# Altium schematic sheet Z18B_STMF_SL_PP0_180928.SchDoc
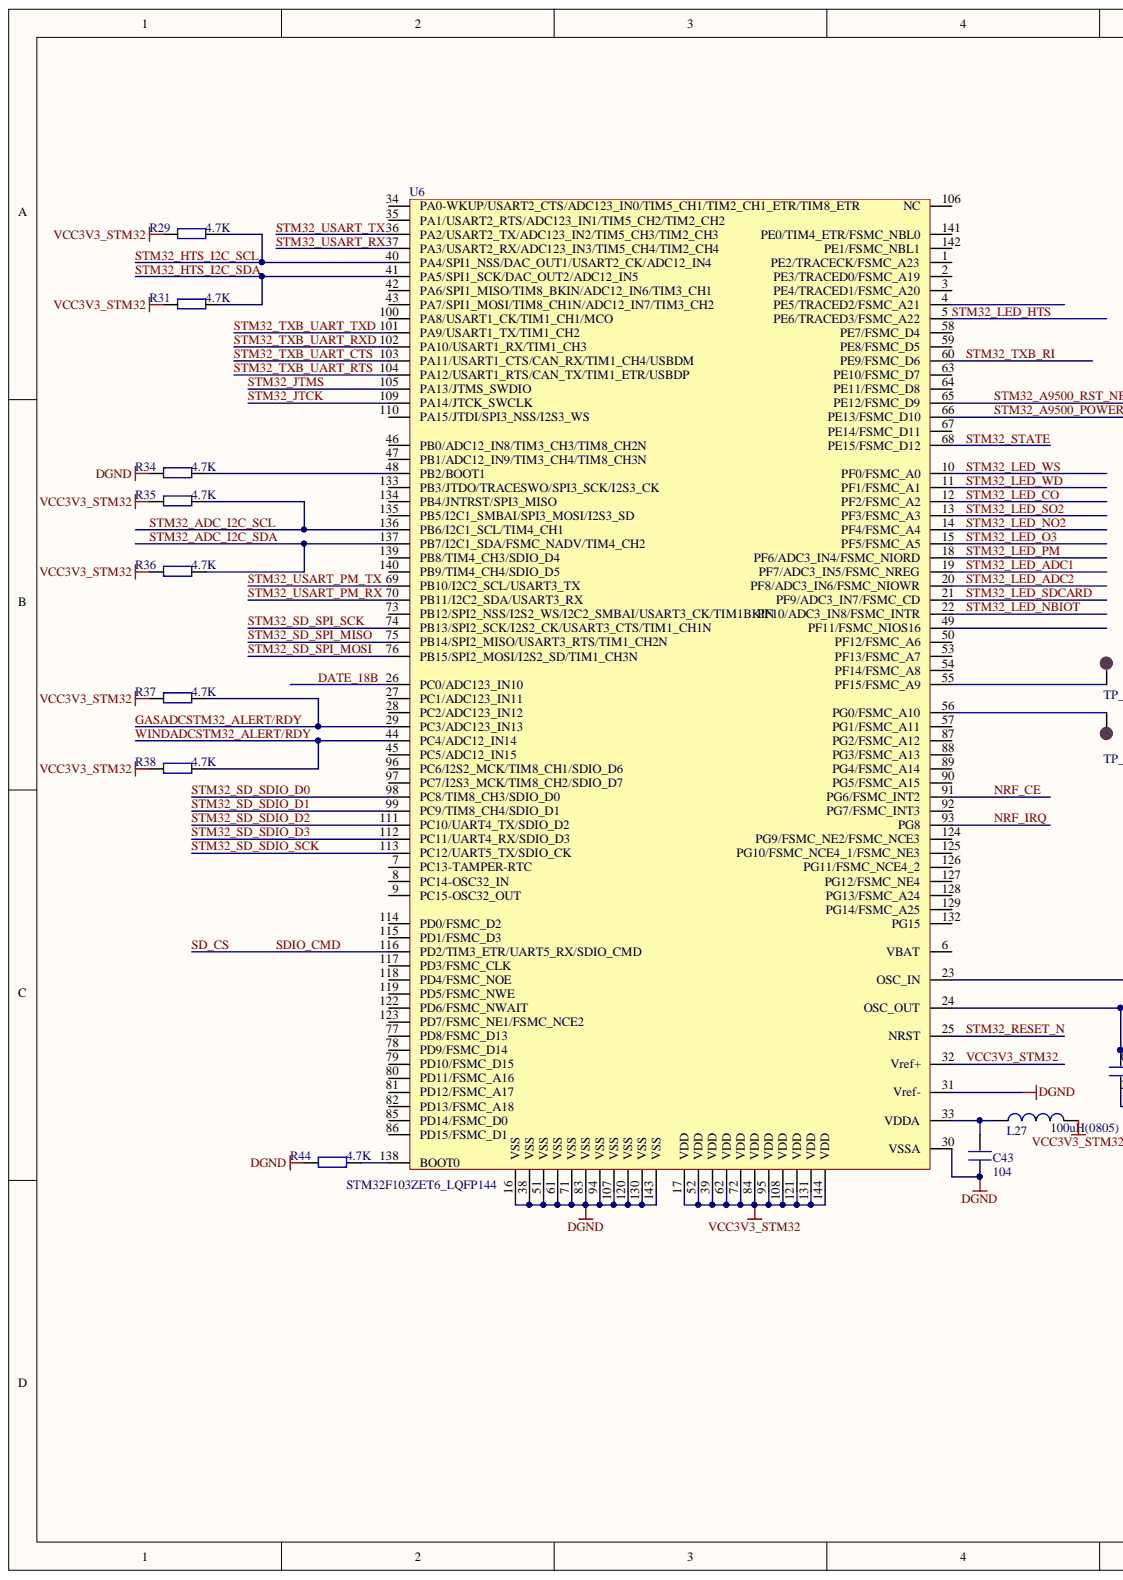
[diagram p0: Z18B_STMF_SL_PP0_180928.SchDoc, left]
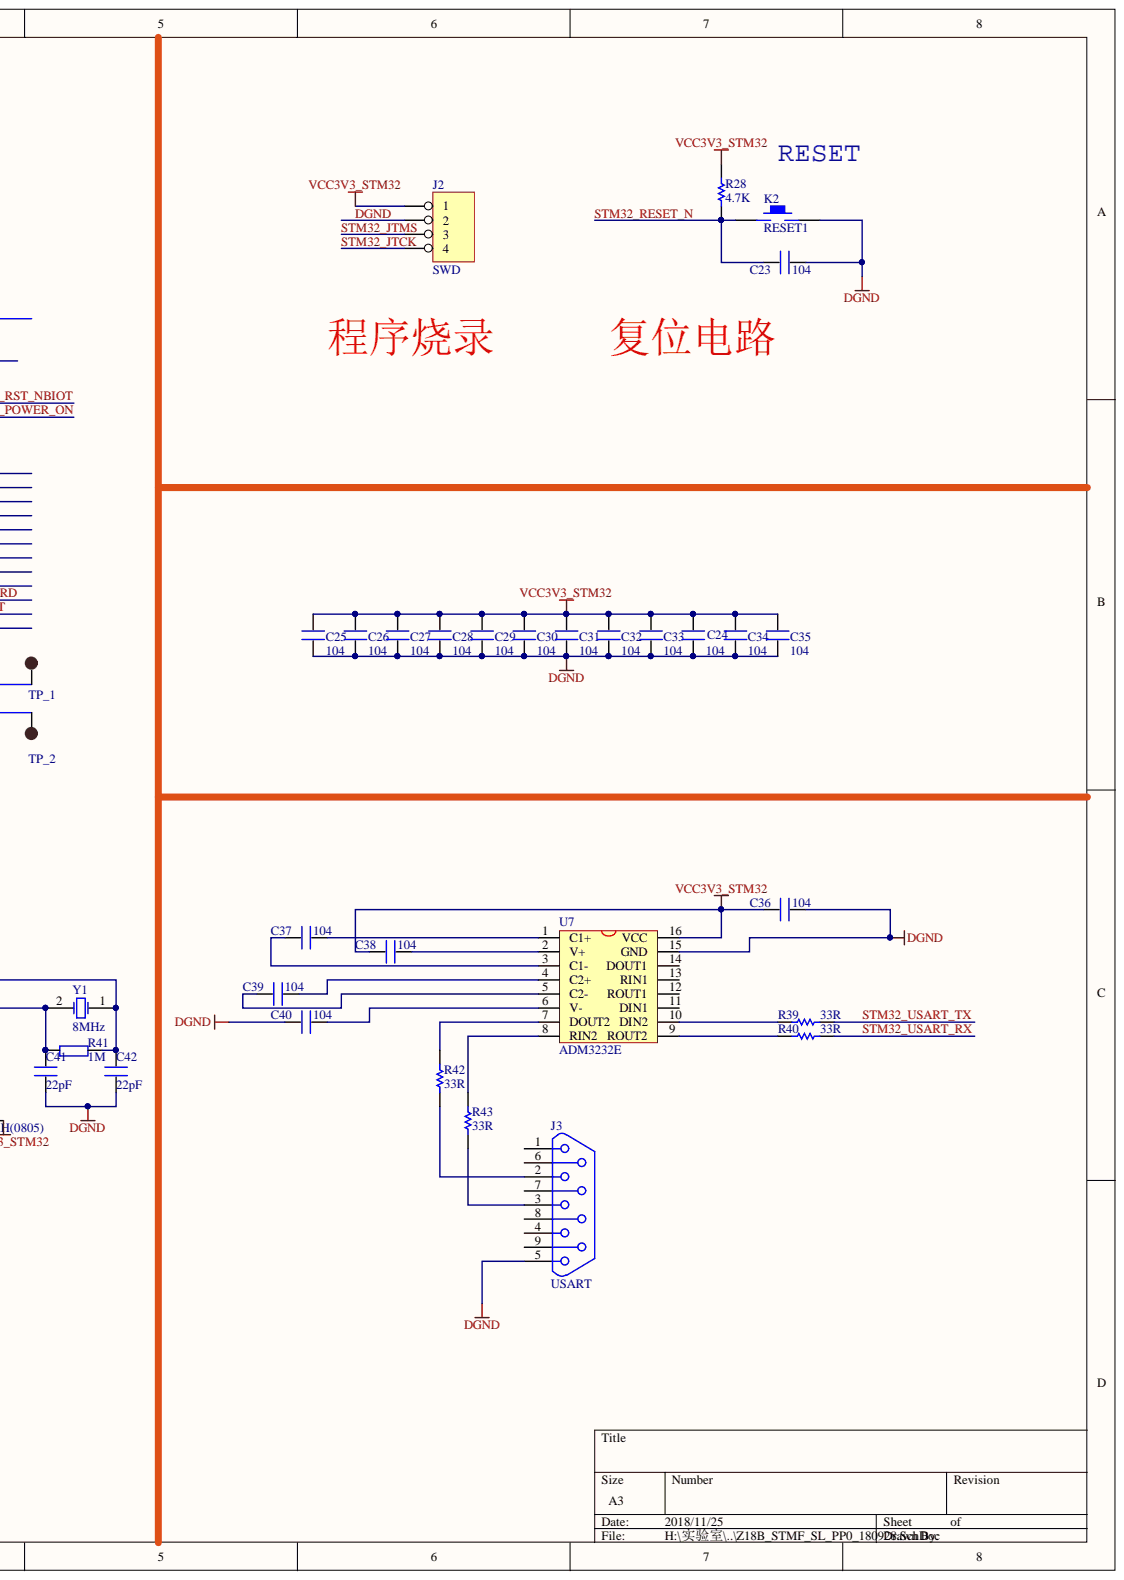
[diagram p1: Z18B_STMF_SL_PP0_180928.SchDoc, right]

## Parts
C23  104  [C0603]
C24  104  [C0603]
C25  104  [C0603]
C26  104  [C0603]
C27  104  [C0603]
C28  104  [C0603]
C29  104  [C0603]
C30  104  [C0603]
C31  104  [C0603]
C32  104  [C0603]
C33  104  [C0603]
C34  104  [C0603]
C35  104  [C0603]
C36  104  [C0603]
C37  104  [C0603]
C38  104  [C0603]
C39  104  [C0603]
C40  104  [C0603]
C41  22pF  [C0603]
C42  22pF  [C0603]
C43  104  [C0603]
J2  SWD  [SIP-2.0-4]
J3  USART  [DB9]
L27  100uH(0805)  [0805]
R28  4.7K  [R0603]
R29  4.7K  [R0603]
R31  4.7K  [R0603]
R34  4.7K  [R0603]
R35  4.7K  [R0603]
R36  4.7K  [R0603]
R37  4.7K  [R0603]
R38  4.7K  [R0603]
R39  value 33R  [R0603]  (hidden comment: Res1)
R40  value 33R  [R0603]  (hidden comment: Res1)
R41  1M  [R0603]
R42  value 33R  [R0603]  (hidden comment: Res1)
R43  value 33R  [R0603]  (hidden comment: Res1)
R44  4.7K  [R0603]
RESET1  K2  [KEY_M]
TP_1  TEST POINT  [TP_2mm]  (value not drawn)
TP_2  TEST POINT  [TP_2mm]  (value not drawn)
U6  STM32F103ZET6_LQFP144  [LQFP144]
U7  ADM3232E  [SOP16M]
Y1  8MHz  [3225晶振 - pin2]

## Nets
DATE_18B: U6.26(PC0/ADC123_IN10)
DGND: C23.2 C24.2 C25.2 C26.1 C27.1 C28.1 C29.1 C30.1 C31.2 C32.2 C33.2 C34.2 C35.2 C36.2 C40.1 C41.1 C42.1 C43.1 J2.2 J3.5 R34.2 R44.2 RESET1.2 U6.16(VSS) U6.30(VSSA) U6.31(Vref-) U6.38(VSS) U6.51(VSS) U6.61(VSS) U6.71(VSS) U6.83(VSS) U6.94(VSS) U6.107(VSS) U6.120(VSS) U6.130(VSS) U6.143(VSS) U7.15(GND)
GASADCSTM32_ALERT/RDY: R37.1 U6.29(PC3/ADC123_IN13)
NRF_CE: U6.91(PG6/FSMC_INT2)
NRF_IRQ: U6.93(PG8)
SD_CS: U6.116(PD2/TIM3_ETR/UART5_RX/SDIO_CMD)
STM32_A9500_POWER_ON: U6.66(PE13/FSMC_D10)
STM32_A9500_RST_NBIOT: U6.65(PE12/FSMC_D9)
STM32_ADC_I2C_SCL: R35.1 U6.136(PB6/I2C1_SCL/TIM4_CH1)
STM32_ADC_I2C_SDA: R36.1 U6.137(PB7/I2C1_SDA/FSMC_NADV/TIM4_CH2)
STM32_HTS_I2C_SCL: R29.1 U6.40(PA4/SPI1_NSS/DAC_OUT1/USART2_CK/ADC12_IN4)
STM32_HTS_I2C_SDA: R31.1 U6.41(PA5/SPI1_SCK/DAC_OUT2/ADC12_IN5)
STM32_JTCK: J2.4 U6.109(PA14/JTCK_SWCLK)
STM32_JTMS: J2.3 U6.105(PA13/JTMS_SWDIO)
STM32_LED_ADC1: U6.19(PF7/ADC3_IN5/FSMC_NREG)
STM32_LED_ADC2: U6.20(PF8/ADC3_IN6/FSMC_NIOWR)
STM32_LED_CO: U6.12(PF2/FSMC_A2)
STM32_LED_HTS: U6.5(PE6/TRACED3/FSMC_A22)
STM32_LED_NBIOT: U6.22(PF10/ADC3_IN8/FSMC_INTR)
STM32_LED_NO2: U6.14(PF4/FSMC_A4)
STM32_LED_O3: U6.15(PF5/FSMC_A5)
STM32_LED_PM: U6.18(PF6/ADC3_IN4/FSMC_NIORD)
STM32_LED_SDCARD: U6.21(PF9/ADC3_IN7/FSMC_CD)
STM32_LED_SO2: U6.13(PF3/FSMC_A3)
STM32_LED_WD: U6.11(PF1/FSMC_A1)
STM32_LED_WS: U6.10(PF0/FSMC_A0)
STM32_RESET_N: C23.1 R28.1 RESET1.1 U6.25(NRST)
STM32_SD_SDIO_D0: U6.98(PC8/TIM8_CH3/SDIO_D0)
STM32_SD_SDIO_D1: U6.99(PC9/TIM8_CH4/SDIO_D1)
STM32_SD_SDIO_D2: U6.111(PC10/UART4_TX/SDIO_D2)
STM32_SD_SDIO_D3: U6.112(PC11/UART4_RX/SDIO_D3)
STM32_SD_SDIO_SCK: U6.113(PC12/UART5_TX/SDIO_CK)
STM32_SD_SPI_MISO: U6.75(PB14/SPI2_MISO/USART3_RTS/TIM1_CH2N)
STM32_SD_SPI_MOSI: U6.76(PB15/SPI2_MOSI/I2S2_SD/TIM1_CH3N)
STM32_SD_SPI_SCK: U6.74(PB13/SPI2_SCK/I2S2_CK/USART3_CTS/TIM1_CH1N)
STM32_STATE: U6.68(PE15/FSMC_D12)
STM32_TXB_RI: U6.60(PE9/FSMC_D6)
STM32_TXB_UART_CTS: U6.103(PA11/USART1_CTS/CAN_RX/TIM1_CH4/USBDM)
STM32_TXB_UART_RTS: U6.104(PA12/USART1_RTS/CAN_TX/TIM1_ETR/USBDP)
STM32_TXB_UART_RXD: U6.102(PA10/USART1_RX/TIM1_CH3)
STM32_TXB_UART_TXD: U6.101(PA9/USART1_TX/TIM1_CH2)
STM32_USART_PM_RX: U6.70(PB11/I2C2_SDA/USART3_RX)
STM32_USART_PM_TX: U6.69(PB10/I2C2_SCL/USART3_TX)
STM32_USART_RX: R40.2 U6.37(PA3/USART2_RX/ADC123_IN3/TIM5_CH4/TIM2_CH4)
STM32_USART_TX: R39.2 U6.36(PA2/USART2_TX/ADC123_IN2/TIM5_CH3/TIM2_CH3)
VCC3V3_STM32: C24.1 C25.1 C26.2 C27.2 C28.2 C29.2 C30.2 C31.1 C32.1 C33.1 C34.1 C35.1 C36.1 C38.1 J2.1 L27.2 R28.2 R29.2 R31.2 R35.2 R36.2 R37.2 R38.2 U6.17(VDD) U6.32(Vref+) U6.39(VDD) U6.52(VDD) U6.62(VDD) U6.72(VDD) U6.84(VDD) U6.95(VDD) U6.108(VDD) U6.121(VDD) U6.131(VDD) U6.144(VDD) U7.16(VCC)
WINDADCSTM32_ALERT/RDY: R38.1 U6.44(PC4/ADC12_IN14)
NetC37_1: C37.1 U7.3(C1-)
NetC37_2: C37.2 U7.1(C1+)
NetC38_2: C38.2 U7.2(V+)
NetC39_1: C39.1 U7.5(C2-)
NetC39_2: C39.2 U7.4(C2+)
NetC40_2: C40.2 U7.6(V-)
NetC41_2: C41.2 R41.1 U6.24(OSC_OUT) Y1.2
NetC42_2: C42.2 R41.2 U6.23(OSC_IN) Y1.1
NetC43_2: C43.2 L27.1 U6.33(VDDA)
NetJ3_2: J3.2 R42.1
NetJ3_3: J3.3 R43.2
NetR34_1: R34.1 U6.48(PB2/BOOT1)
NetR39_1: R39.1 U7.10(DIN2)
NetR40_1: R40.1 U7.9(ROUT2)
NetR42_2: R42.2 U7.7(DOUT2)
NetR43_1: R43.1 U7.8(RIN2)
NetR44_1: R44.1 U6.138(BOOT0)
NetTP_1_1: TP_1.1 U6.55(PF15/FSMC_A9)
NetTP_2_1: TP_2.1 U6.56(PG0/FSMC_A10)

Not connected: J3 1 4 6 7 8 9; U6 1(PE2/TRACECK/FSMC_A23) 2(PE3/TRACED0/FSMC_A19) 3(PE4/TRACED1/FSMC_A20) 4(PE5/TRACED2/FSMC_A21) 6(VBAT) 7(PC13-TAMPER-RTC) 8(PC14-OSC32_IN) 9(PC15-OSC32_OUT) 27(PC1/ADC123_IN11) 28(PC2/ADC123_IN12) 34(PA0-WKUP/USART2_CTS/ADC123_IN0/TIM5_CH1/TIM2_CH1_ETR/TIM8_ETR) 35(PA1/USART2_RTS/ADC123_IN1/TIM5_CH2/TIM2_CH2) 42(PA6/SPI1_MISO/TIM8_BKIN/ADC12_IN6/TIM3_CH1) 43(PA7/SPI1_MOSI/TIM8_CH1N/ADC12_IN7/TIM3_CH2) 45(PC5/ADC12_IN15) 46(PB0/ADC12_IN8/TIM3_CH3/TIM8_CH2N) 47(PB1/ADC12_IN9/TIM3_CH4/TIM8_CH3N) 49(PF11/FSMC_NIOS16) 50(PF12/FSMC_A6) 53(PF13/FSMC_A7) 54(PF14/FSMC_A8) 57(PG1/FSMC_A11) 58(PE7/FSMC_D4) 59(PE8/FSMC_D5) 63(PE10/FSMC_D7) 64(PE11/FSMC_D8) 67(PE14/FSMC_D11) 73(PB12/SPI2_NSS/I2S2_WS/I2C2_SMBAI/USART3_CK/TIM1BKIN) 77(PD8/FSMC_D13) 78(PD9/FSMC_D14) 79(PD10/FSMC_D15) 80(PD11/FSMC_A16) 81(PD12/FSMC_A17) 82(PD13/FSMC_A18) 85(PD14/FSMC_D0) 86(PD15/FSMC_D1) 87(PG2/FSMC_A12) 88(PG3/FSMC_A13) 89(PG4/FSMC_A14) 90(PG5/FSMC_A15) 92(PG7/FSMC_INT3) 96(PC6/I2S2_MCK/TIM8_CH1/SDIO_D6) 97(PC7/I2S3_MCK/TIM8_CH2/SDIO_D7) 100(PA8/USART1_CK/TIM1_CH1/MCO) 106(NC) 110(PA15/JTDI/SPI3_NSS/I2S3_WS) 114(PD0/FSMC_D2) 115(PD1/FSMC_D3) 117(PD3/FSMC_CLK) 118(PD4/FSMC_NOE) 119(PD5/FSMC_NWE) 122(PD6/FSMC_NWAIT) 123(PD7/FSMC_NE1/FSMC_NCE2) 124(PG9/FSMC_NE2/FSMC_NCE3) 125(PG10/FSMC_NCE4_1/FSMC_NE3) 126(PG11/FSMC_NCE4_2) 127(PG12/FSMC_NE4) 128(PG13/FSMC_A24) 129(PG14/FSMC_A25) 132(PG15) 133(PB3/JTDO/TRACESWO/SPI3_SCK/I2S3_CK) 134(PB4/JNTRST/SPI3_MISO) 135(PB5/I2C1_SMBAI/SPI3_MOSI/I2S3_SD) 139(PB8/TIM4_CH3/SDIO_D4) 140(PB9/TIM4_CH4/SDIO_D5) 141(PE0/TIM4_ETR/FSMC_NBL0) 142(PE1/FSMC_NBL1); U7 11(DIN1) 12(ROUT1) 13(RIN1) 14(DOUT1)

## Library data of the parts
C23-C35 C43 = C: Component Kind: Standard; Library Name: 战舰STM32开发板底板封装库.IntLib
C36-C42 = C_1: Component Kind: Standard; Library Name: 战舰STM32开发板底板封装库.IntLib
J2 = 4 HEADER: 4 Pin Header; Component Kind: Standard
J3 = DB9: Component Kind: Standard; Library Name: 战舰STM32开发板底板封装库.IntLib
L27 = Inductor: Code_IEC: 1005; Value: 10mH; Code_JEITA: 0402; Component Kind: Standard; Description: Inductor; LatestRevisionDate: 29-May-2009; LatestRevisionNote: IPC-7351 Footprint Added.; Library Name: Miscellaneous Devices.IntLib; PackageDescription: Chip Inductor; PackageReference: 0402-A; Published: 8-Jun-2000; Signal Integrity: Inductor; Simulation: INDUCTOR; Publisher: Altium Limited
R28 = Res1: Resistor; Value: 1K; Component Kind: Standard; LatestRevisionDate: 17-Jul-2002; LatestRevisionNote: Re-released for DXP Platform.; Library Name: Miscellaneous Devices.IntLib; PackageDescription: Axial Device, Thru-Hole; 2 Leads; 0.3 in Pin Spacing; PackageReference: AXIAL-0.3; Published: 8-Jun-2000; Signal Integrity: Res; Simulation: RESISTOR; Publisher: Altium Limited
R29 R31 R34-R38 R44 = R: Component Kind: Standard; Library Name: 战舰STM32开发板底板封装库.IntLib
R39 R40 R42 R43 = Res1: Resistor; Component Kind: Standard; LatestRevisionDate: 17-Jul-2002; LatestRevisionNote: Re-released for DXP Platform.; Library Name: Miscellaneous Devices.IntLib; PackageDescription: Axial Device, Thru-Hole; 2 Leads; 0.3 in Pin Spacing; PackageReference: AXIAL-0.3; Published: 8-Jun-2000; Signal Integrity: Res; Simulation: RESISTOR; Publisher: Altium Limited
R41 = R_1: Component Kind: Standard; Library Name: 战舰STM32开发板底板封装库.IntLib
RESET1 = KEY_M: Component Kind: Standard; Library Name: 战舰STM32开发板底板封装库.IntLib
TP_1 TP_2 = TEST-POINT: 测试点; Component Kind: Standard; Library Name: 战舰STM32开发板底板封装库.IntLib
U6 = STM32F103ZET6: Component Kind: Standard; Description: STM32F103ZET6; Library Name: 战舰STM32开发板底板封装库.IntLib
U7 = MAX3232: Component Kind: Standard; Library Name: 战舰STM32开发板底板封装库.IntLib
Y1 = XTAL: Crystal Oscillator; Component Kind: Standard; LatestRevisionDate: 17-Jul-2002; LatestRevisionNote: Re-released for DXP Platform.; Published: 8-Jun-2000; Simulation: XTAL; Library Name: 战舰STM32开发板底板封装库.IntLib; Publisher: Altium Limited
